# Altium schematic sheet [5]-POWER.SchDoc([5]-POWER)
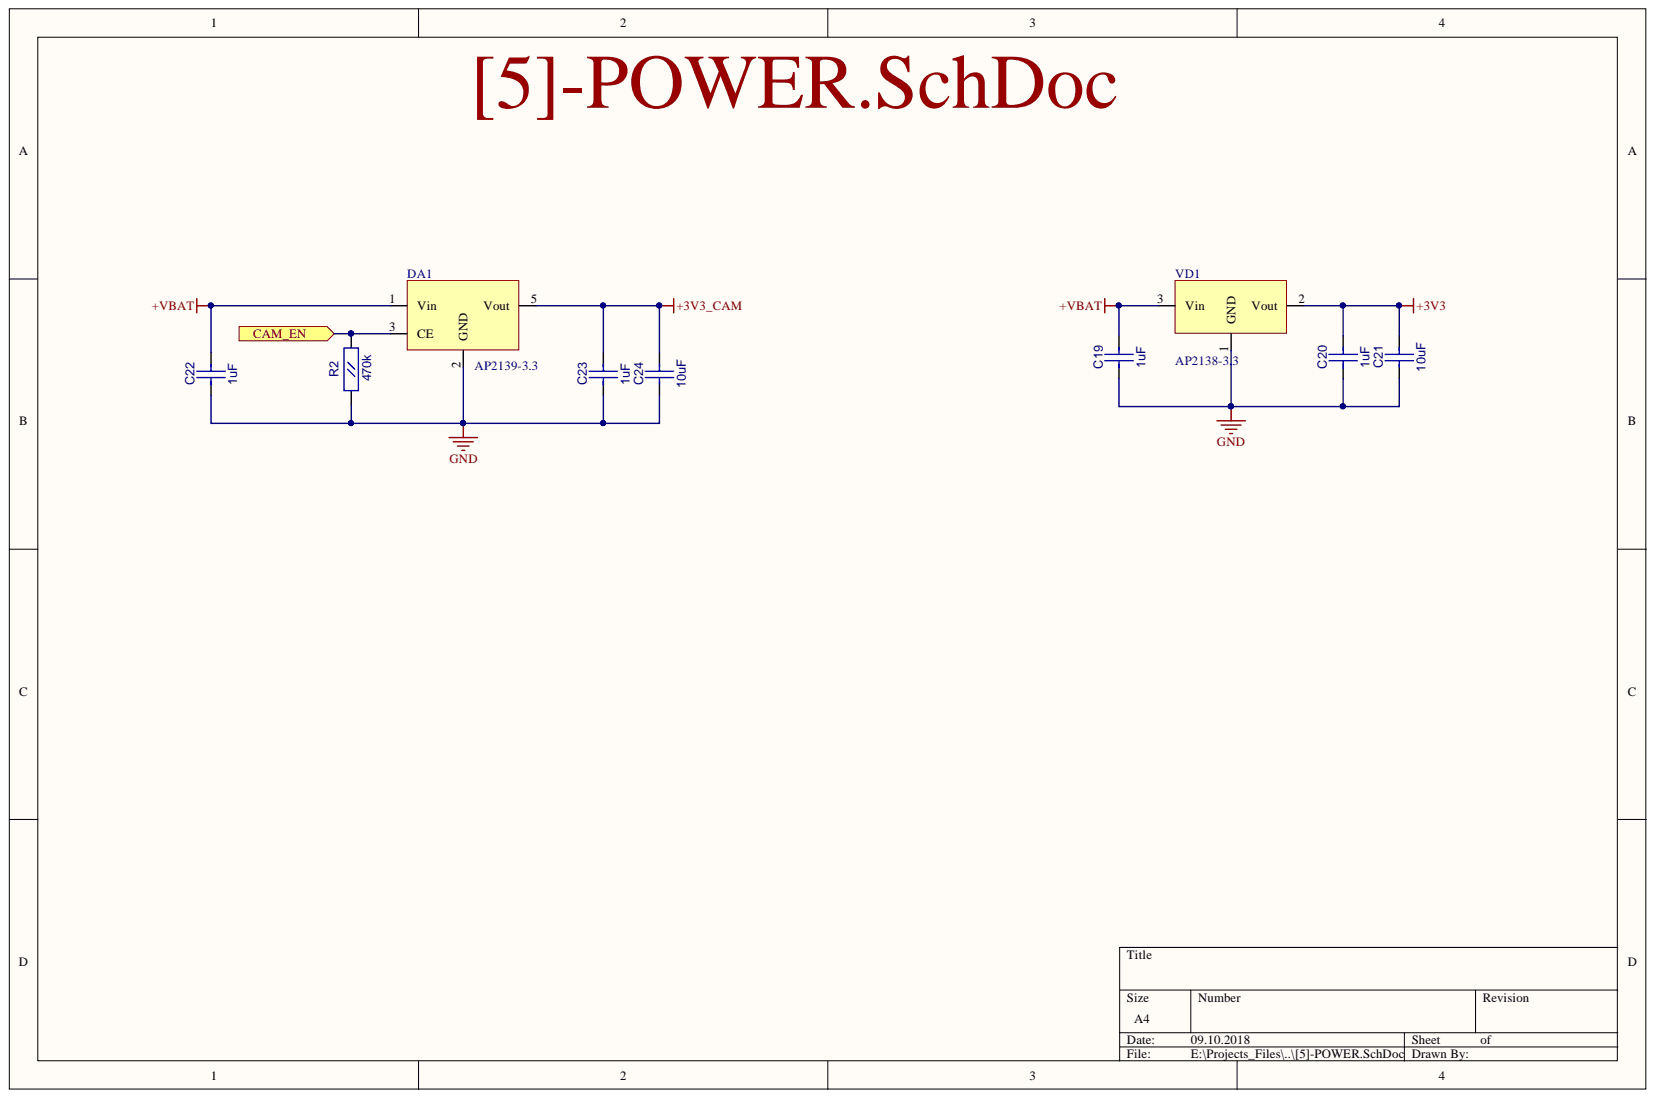
[diagram p0: [5]-POWER.SchDoc]

## Parts
C19  1uF  [CC0603]
C20  1uF  [CC0603]
C21  10uF  [CC0805]
C22  1uF  [CC0603]
C23  1uF  [CC0603]
C24  10uF  [CC0805]
DA1  AP2139-3.3  [SOT23-5]
R2  470k  [RC0603]
VD1  AP2138-3.3  [SOT-23(123)]

## Ports
CAM_EN

## Nets
+3V3: C20.2 C21.2 VD1.2
+3V3_CAM: C23.2 C24.2 DA1.5(Vout)
+VBAT: C19.2 C22.2 DA1.1(Vin) VD1.3
GND: C19.1 C20.1 C21.1 C22.1 C23.1 C24.1 DA1.2(GND) R2.1 VD1.1
CAM_EN: DA1.3(CE) R2.2 ; port CAM_EN

## Library data of the parts
C19 C20 C22 C23 = CC0603: Value: 1uF; Description: CC0603; Component Kind: Standard; Library Name: gprs_modem_2.SchLib
C21 C24 = CC0805: Value: 10uF; Description: CC0805; Component Kind: Standard; Library Name: gprs_modem_2.SchLib
DA1 = AP2139: Value: AP2139-3.3; Component Kind: Standard; Library Name: fizik_lib.SchLib
R2 = RC0603: Value: 470k; Description: RC0603; Component Kind: Standard; Library Name: fizik_lib.SchLib
VD1 = AP2138: Value: AP2138-3.3; Component Kind: Standard; Library Name: fizik_lib.SchLib
